# Altium schematic sheet Core.SchDoc
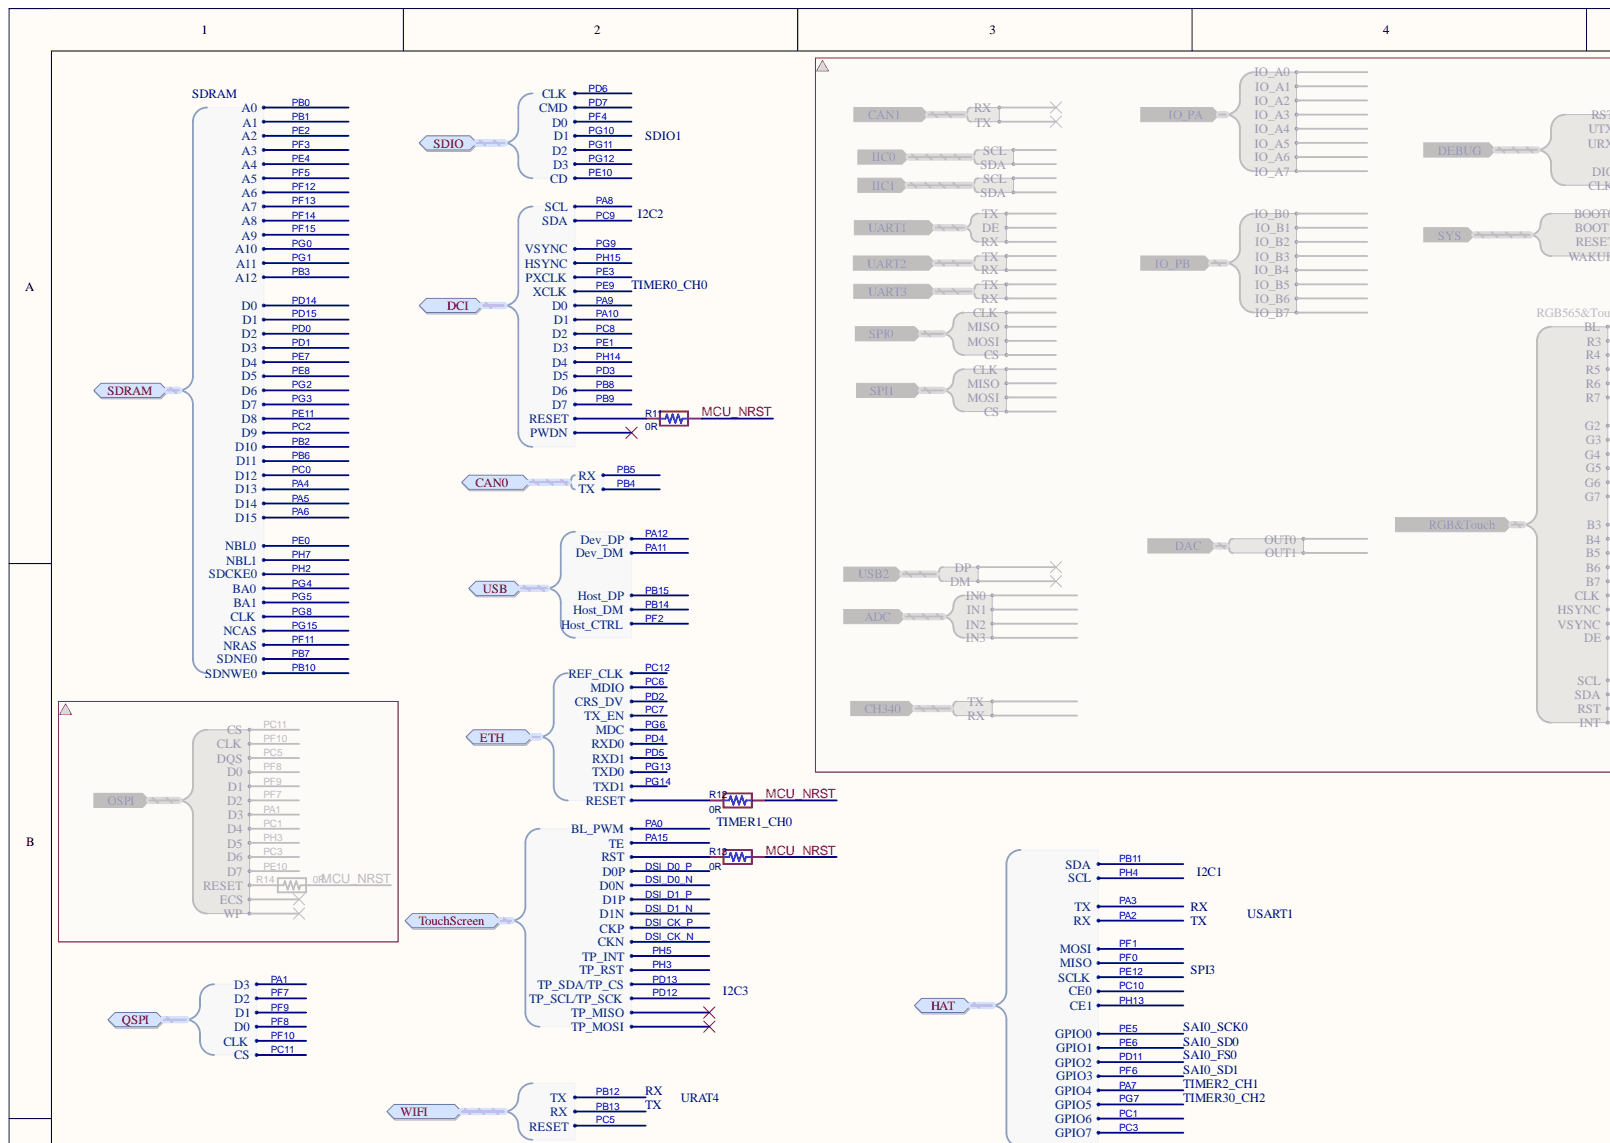
[diagram p0: Core.SchDoc, top left]
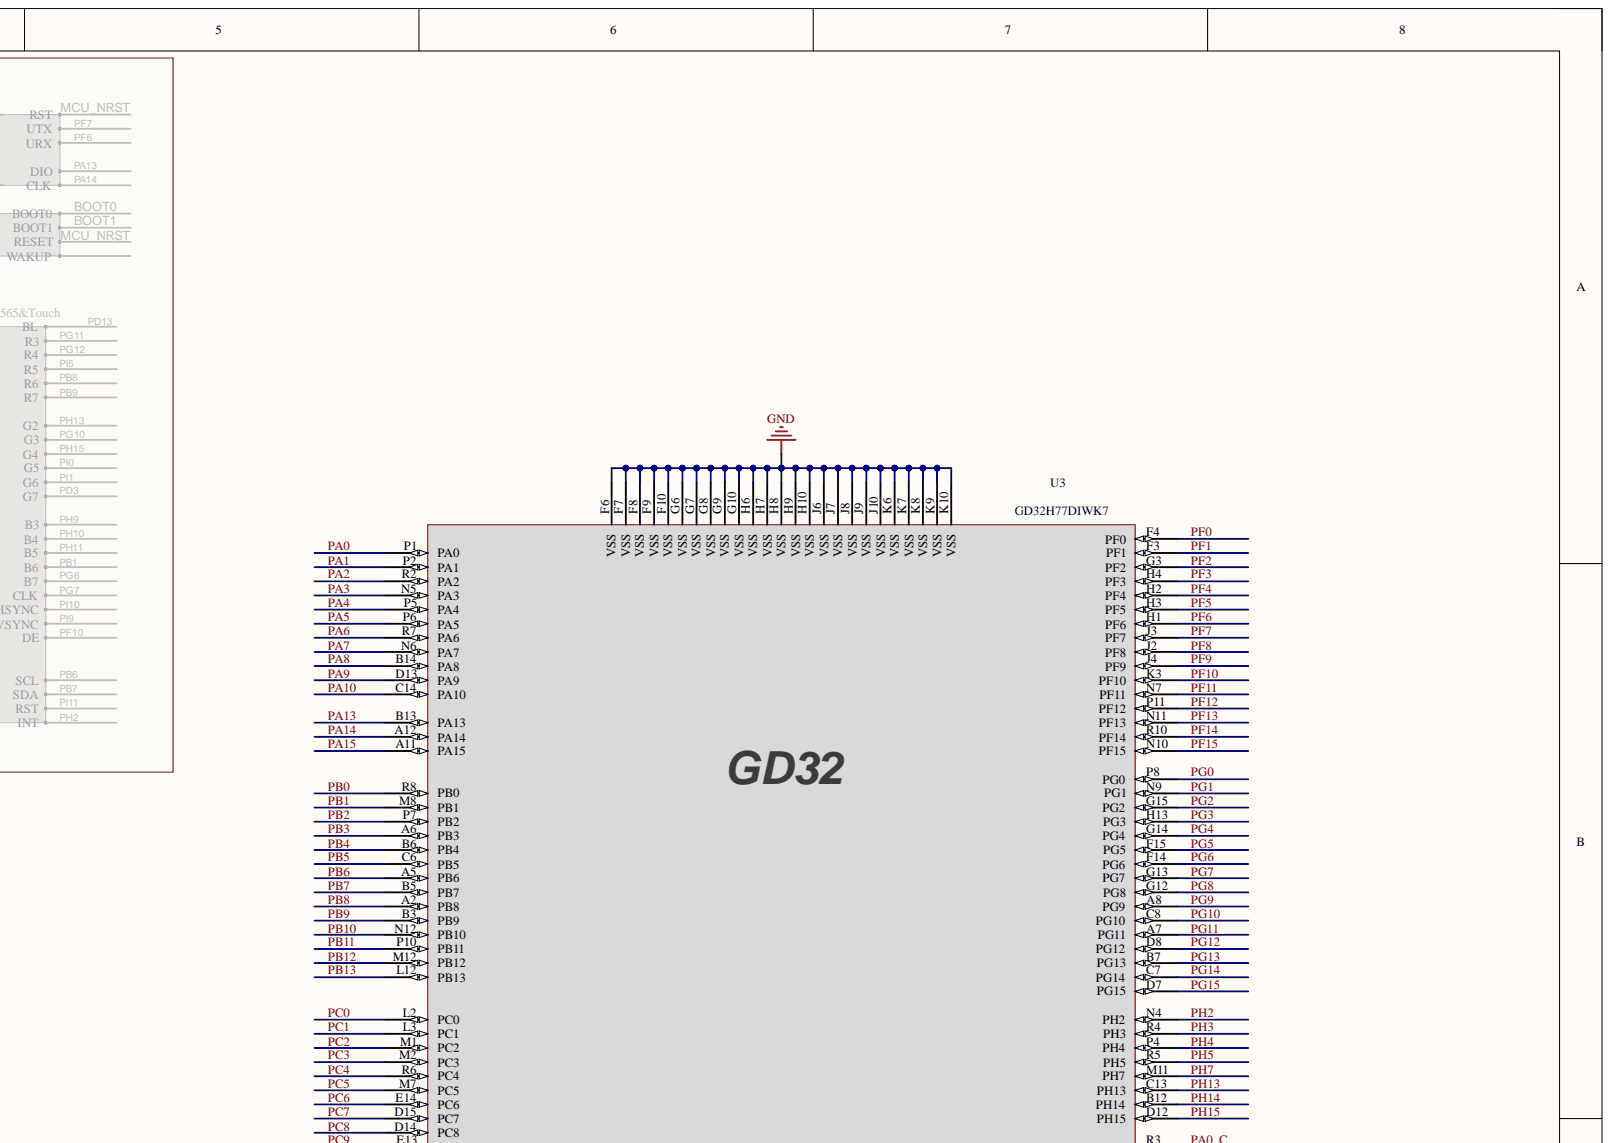
[diagram p1: Core.SchDoc, top right]
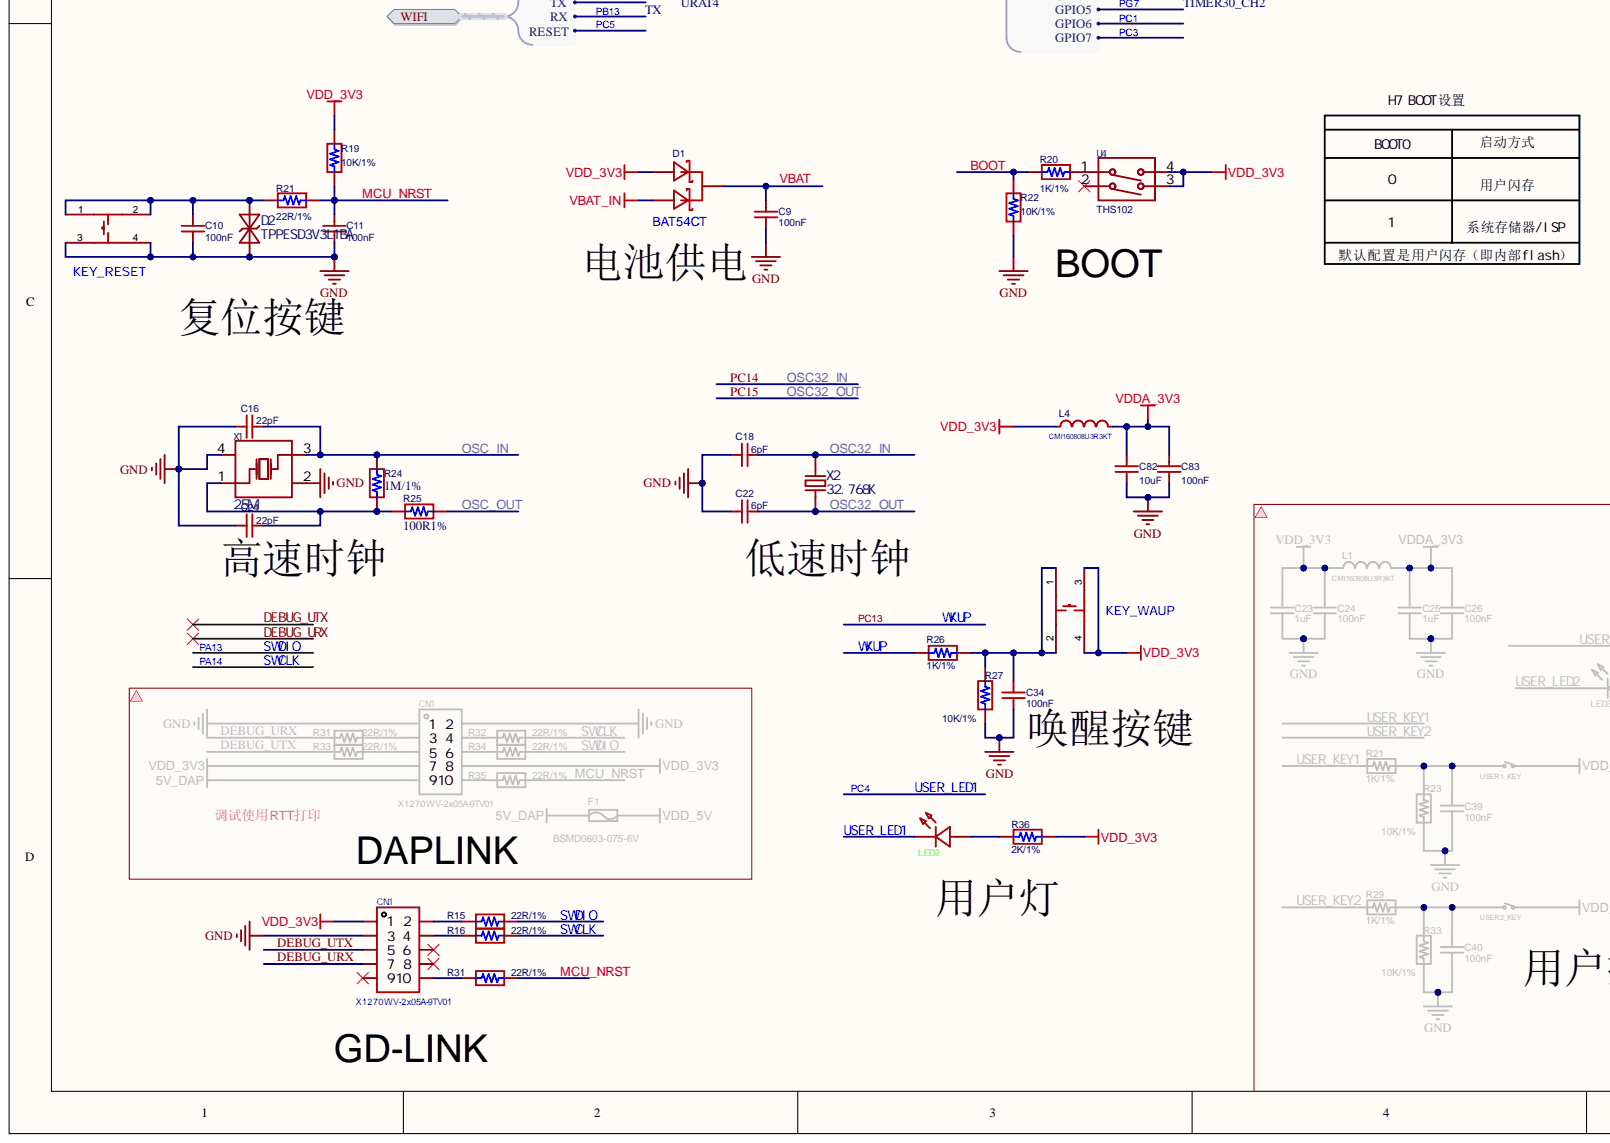
[diagram p2: Core.SchDoc, bottom left]
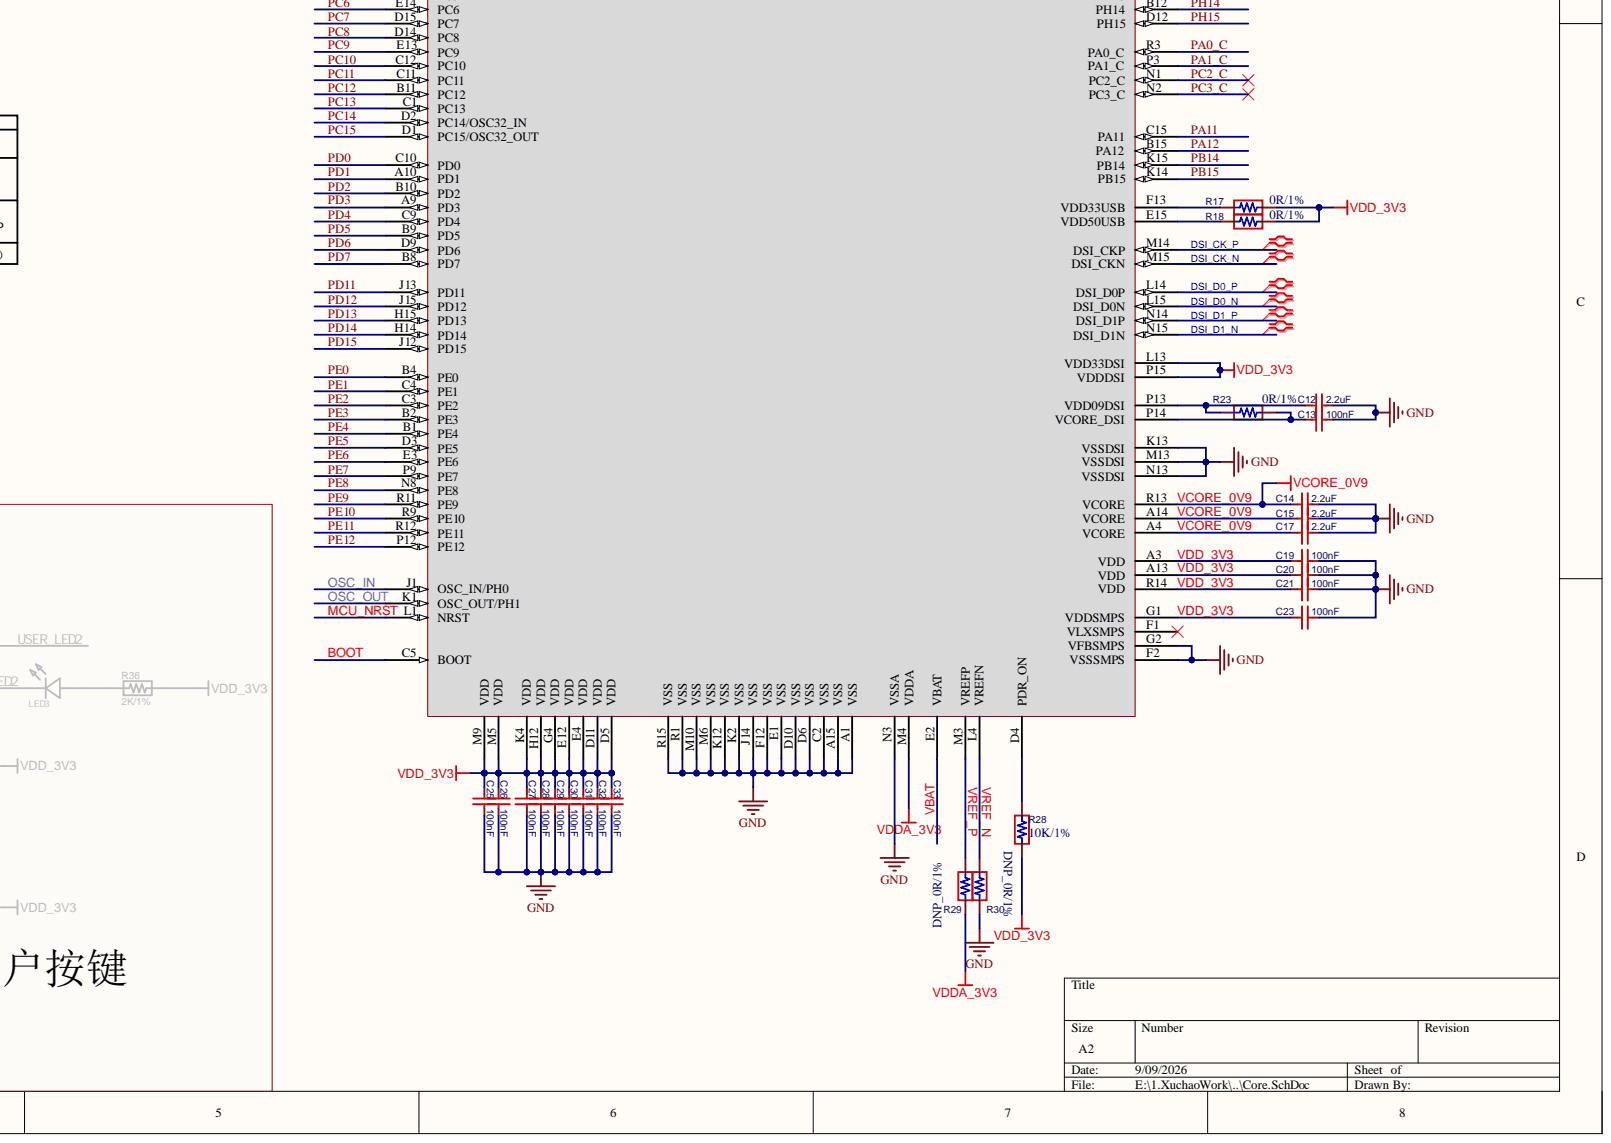
[diagram p3: Core.SchDoc, bottom right]

## Parts
C9  100nF  [C0402]
C10  100nF  [C0603]
C11  100nF  [C0603]
C12  2.2uF  [C0402]
C13  100nF  [C0402]
C14  2.2uF  [C0402]
C15  2.2uF  [C0402]
C16  22pF  [C0402]
C17  2.2uF  [C0402]
C18  6pF  [C0402]
C19  100nF  [C0402]
C20  100nF  [C0402]
C21  100nF  [C0402]
C22  6pF  [C0402]
C23  100nF  [C0402]
C24  22pF  [C0402]
C25  100nF  [C0402]
C26  100nF  [C0402]
C27  100nF  [C0402]
C28  100nF  [C0402]
C29  100nF  [C0402]
C30  100nF  [C0402]
C31  100nF  [C0402]
C32  100nF  [C0402]
C33  100nF  [C0402]
C34  100nF  [C0603]
C82  10uF  [C0402]
C83  100nF  [C0402]
CN1  X1270WV-2x05A-9TV01  [IDC-TH_10P-P1.27-X1270WV-2X05A-6TV01]
D1  BAT54CT  [SOT-523-3_L1.6-W0.8-P1.00-LS1.6-BR]
D2  TPPESD3V3L1BA  [SOD-323_L1.7-W1.3-LS2.5-BI]
L4  CMI160808U3R3KT  [L0603]
LED2  LED  [LED 0603B]
R11  0R  [R0603]
R12  0R  [R0603]
R13  0R  [R0603]
R15  22R/1%  [R0402]
R16  22R/1%  [R0402]
R17  0R/1%  [R0402]
R18  0R/1%  [R0402]
R19  10K/1%  [R0402]
R20  1K/1%  [R0402]
R21  22R/1%  [R0402]
R22  10K/1%  [R0402]
R23  0R/1%  [R0402]
R24  1M/1%  [R0402]
R25  100R1%  [R0402]
R26  1K/1%  [R0402]
R27  10K/1%  [R0402]
R28  10K/1%  [R0402]
R29  DNP_0R/1%  [R0402]
R30  DNP_0R/1%  [R0402]
R31  22R/1%  [R0402]
R36  2K/1%  [R0402]
SW1  KEY_RESET  [SW-SMD_4P-L4.2-W3.3-P2.15-LS5.1]
SW2  KEY_WAUP  [SW-SMD_4P-L4.2-W3.3-P2.15-LS5.1]
U3  GD32H77DIWK7  [BGA176_10x10X0.68]
U4  THS102  [SW-SMD_THS102]
X1  25M  [OSC 3225-4P]
X2  32.768K  [OSC-SMD_L3.2-W1.5]

## Ports
CAN0, CAN0.RX, CAN0.TX, DCI, DCI.D0, DCI.D1, DCI.D2, DCI.D3, DCI.D4, DCI.D5, DCI.D6, DCI.D7, DCI.HSYNC, DCI.PWDN, DCI.PXCLK, DCI.RESET, DCI.SCL, DCI.SDA, DCI.VSYNC, DCI.XCLK, ETH, ETH.CRS_DV, ETH.MDC, ETH.MDIO, ETH.REF_CLK, ETH.RESET, ETH.RXD0, ETH.RXD1, ETH.TX_EN, ETH.TXD0, ETH.TXD1, HAT, HAT.CE0, HAT.CE1, HAT.GPIO0, HAT.GPIO1, HAT.GPIO2, HAT.GPIO3, HAT.GPIO4, HAT.GPIO5, HAT.GPIO6, HAT.GPIO7, HAT.MISO, HAT.MOSI, HAT.RX, HAT.SCL, HAT.SCLK, HAT.SDA, HAT.TX, QSPI, QSPI.CLK, QSPI.CS, QSPI.D0, QSPI.D1, QSPI.D2, QSPI.D3, SDIO, SDIO.CD, SDIO.CLK, SDIO.CMD, SDIO.D0, SDIO.D1, SDIO.D2, SDIO.D3, SDRAM, SDRAM.A0, SDRAM.A1, SDRAM.A2, SDRAM.A3, SDRAM.A4, SDRAM.A5, SDRAM.A6, SDRAM.A7, SDRAM.A8, SDRAM.A9, SDRAM.A10, SDRAM.A11, SDRAM.A12, SDRAM.BA0, SDRAM.BA1, SDRAM.CLK, SDRAM.D0, SDRAM.D1, SDRAM.D2, SDRAM.D3, SDRAM.D4, SDRAM.D5, SDRAM.D6, SDRAM.D7, SDRAM.D8, SDRAM.D9, SDRAM.D10, SDRAM.D11, SDRAM.D12, SDRAM.D13, SDRAM.D14, SDRAM.D15, SDRAM.NBL0, SDRAM.NBL1, SDRAM.NCAS, SDRAM.NRAS, SDRAM.SDCKE0, SDRAM.SDNE0, SDRAM.SDNWE0, TouchScreen, TouchScreen.BL_PWM, TouchScreen.CKN, TouchScreen.CKP, TouchScreen.D0N, TouchScreen.D0P, TouchScreen.D1N, TouchScreen.D1P, TouchScreen.RST, TouchScreen.TE, TouchScreen.TP_INT, TouchScreen.TP_MISO, TouchScreen.TP_MOSI, TouchScreen.TP_RST, TouchScreen.TP_SCL/TP_SCK, TouchScreen.TP_SDA/TP_CS, USB, USB.Dev_DM, USB.Dev_DP, USB.Host_CTRL, USB.Host_DM, USB.Host_DP, WIFI, WIFI.RESET, WIFI.RX, WIFI.TX

## Nets
GND: C9.1 C10.1 C11.1 C12.1 C13.1 C14.1 C15.1 C16.1 C17.1 C18.1 C19.1 C20.1 C21.1 C22.1 C23.1 C24.1 C25.1 C26.1 C27.1 C28.1 C29.1 C30.1 C31.1 C32.1 C33.1 C34.1 C82.1 C83.1 CN1.3 D2.1 R22.1 R27.2 R30.2 SW1.3 SW1.4 U3.A1(VSS) U3.A15(VSS) U3.C2(VSS) U3.D6(VSS) U3.D10(VSS) U3.E1(VSS) U3.F2(VSSSMPS) U3.F6(VSS) U3.F7(VSS) U3.F8(VSS) U3.F9(VSS) U3.F10(VSS) U3.F12(VSS) U3.G2(VFBSMPS) U3.G6(VSS) U3.G7(VSS) U3.G8(VSS) U3.G9(VSS) U3.G10(VSS) U3.H6(VSS) U3.H7(VSS) U3.H8(VSS) U3.H9(VSS) U3.H10(VSS) U3.J6(VSS) U3.J7(VSS) U3.J8(VSS) U3.J9(VSS) U3.J10(VSS) U3.J14(VSS) U3.K2(VSS) U3.K6(VSS) U3.K7(VSS) U3.K8(VSS) U3.K9(VSS) U3.K10(VSS) U3.K12(VSS) U3.K13(VSSDSI) U3.M6(VSS) U3.M10(VSS) U3.M13(VSSDSI) U3.N3(VSSA) U3.N13(VSSDSI) U3.R1(VSS) U3.R15(VSS) X1.2 X1.4
VBAT_IN: D1.2
VDDA_3V3: C82.2 C83.2 L4.2 R29.2 U3.M4(VDDA)
BOOT: R20.2 R22.2 U3.C5(BOOT)
DCI: R11.2 ; port DCI
DEBUG_URX: CN1.7
DEBUG_UTX: CN1.5
DSI_CK_N: U3.M15(DSI_CKN) ; port TouchScreen
DSI_CK_P: U3.M14(DSI_CKP) ; port TouchScreen
DSI_D0_N: U3.L15(DSI_D0N) ; port TouchScreen
DSI_D0_P: U3.L14(DSI_D0P) ; port TouchScreen
DSI_D1_N: U3.N15(DSI_D1N) ; port TouchScreen
DSI_D1_P: U3.N14(DSI_D1P) ; port TouchScreen
ETH: R12.2 ; port ETH
MCU_NRST: C11.2 R11.1 R12.1 R13.1 R19.1 R21.1 R31.2 U3.L1(NRST)
OSC32_IN: C18.2 U3.D2(PC14/OSC32_IN) X2.2
OSC32_OUT: C22.2 U3.D1(PC15/OSC32_OUT) X2.1
OSC_IN: C16.2 R24.2 U3.J1(OSC_IN/PH0) X1.3
OSC_OUT: R25.2 U3.K1(OSC_OUT/PH1)
PA0: U3.P1(PA0) ; port TouchScreen
PA0_C: U3.R3(PA0_C)
PA1: U3.P2(PA1) ; port QSPI
PA1_C: U3.P3(PA1_C)
PA2: U3.R2(PA2) ; port HAT
PA3: U3.N5(PA3) ; port HAT
PA4: U3.P5(PA4) ; port SDRAM
PA5: U3.P6(PA5) ; port SDRAM
PA6: U3.R7(PA6) ; port SDRAM
PA7: U3.N6(PA7) ; port HAT
PA8: U3.B14(PA8) ; port DCI
PA9: U3.D13(PA9) ; port DCI
PA10: U3.C14(PA10) ; port DCI
PA11: U3.C15(PA11) ; port USB
PA12: U3.B15(PA12) ; port USB
PA13: R15.2 U3.B13(PA13)
PA14: R16.2 U3.A12(PA14)
PA15: U3.A11(PA15) ; port TouchScreen
PB0: U3.R8(PB0) ; port SDRAM
PB1: U3.M8(PB1) ; port SDRAM
PB2: U3.P7(PB2) ; port SDRAM
PB3: U3.A6(PB3) ; port SDRAM
PB4: U3.B6(PB4) ; port CAN0
PB5: U3.C6(PB5) ; port CAN0
PB6: U3.A5(PB6) ; port SDRAM
PB7: U3.B5(PB7) ; port SDRAM
PB8: U3.A2(PB8) ; port DCI
PB9: U3.B3(PB9) ; port DCI
PB10: U3.N12(PB10) ; port SDRAM
PB11: U3.P10(PB11) ; port HAT
PB12: U3.M12(PB12) ; port WIFI
PB13: U3.L12(PB13) ; port WIFI
PB14: U3.K15(PB14) ; port USB
PB15: U3.K14(PB15) ; port USB
PC0: U3.L2(PC0) ; port SDRAM
PC1: U3.L3(PC1) ; port HAT
PC2: U3.M1(PC2) ; port SDRAM
PC2_C: U3.N1(PC2_C)
PC3: U3.M2(PC3) ; port HAT
PC3_C: U3.N2(PC3_C)
PC4: LED2.2 U3.R6(PC4)
PC5: U3.M7(PC5) ; port WIFI
PC6: U3.E14(PC6) ; port ETH
PC7: U3.D15(PC7) ; port ETH
PC8: U3.D14(PC8) ; port DCI
PC9: U3.E13(PC9) ; port DCI
PC10: U3.C12(PC10) ; port HAT
PC11: U3.C11(PC11) ; port QSPI
PC12: U3.B11(PC12) ; port ETH
PC13: R26.2 U3.C1(PC13)
PD0: U3.C10(PD0) ; port SDRAM
PD1: U3.A10(PD1) ; port SDRAM
PD2: U3.B10(PD2) ; port ETH
PD3: U3.A9(PD3) ; port DCI
PD4: U3.C9(PD4) ; port ETH
PD5: U3.B9(PD5) ; port ETH
PD6: U3.D9(PD6) ; port SDIO
PD7: U3.B8(PD7) ; port SDIO
PD11: U3.J13(PD11) ; port HAT
PD12: U3.J15(PD12) ; port TouchScreen
PD13: U3.H15(PD13) ; port TouchScreen
PD14: U3.H14(PD14) ; port SDRAM
PD15: U3.J12(PD15) ; port SDRAM
PE0: U3.B4(PE0) ; port SDRAM
PE1: U3.C4(PE1) ; port DCI
PE2: U3.C3(PE2) ; port SDRAM
PE3: U3.B2(PE3) ; port DCI
PE4: U3.B1(PE4) ; port SDRAM
PE5: U3.D3(PE5) ; port HAT
PE6: U3.E3(PE6) ; port HAT
PE7: U3.P9(PE7) ; port SDRAM
PE8: U3.N8(PE8) ; port SDRAM
PE9: U3.R11(PE9) ; port DCI
PE10: U3.R9(PE10) ; port SDIO
PE11: U3.R12(PE11) ; port SDRAM
PE12: U3.P12(PE12) ; port HAT
PF0: U3.F4(PF0) ; port HAT
PF1: U3.F3(PF1) ; port HAT
PF2: U3.G3(PF2) ; port USB
PF3: U3.H4(PF3) ; port SDRAM
PF4: U3.H2(PF4) ; port SDIO
PF5: U3.H3(PF5) ; port SDRAM
PF6: U3.H1(PF6) ; port HAT
PF7: U3.J3(PF7) ; port QSPI
PF8: U3.J2(PF8) ; port QSPI
PF9: U3.J4(PF9) ; port QSPI
PF10: U3.K3(PF10) ; port QSPI
PF11: U3.N7(PF11) ; port SDRAM
PF12: U3.P11(PF12) ; port SDRAM
PF13: U3.N11(PF13) ; port SDRAM
PF14: U3.R10(PF14) ; port SDRAM
PF15: U3.N10(PF15) ; port SDRAM
PG0: U3.P8(PG0) ; port SDRAM
PG1: U3.N9(PG1) ; port SDRAM
PG2: U3.G15(PG2) ; port SDRAM
PG3: U3.H13(PG3) ; port SDRAM
PG4: U3.G14(PG4) ; port SDRAM
PG5: U3.F15(PG5) ; port SDRAM
PG6: U3.F14(PG6) ; port ETH
PG7: U3.G13(PG7) ; port HAT
PG8: U3.G12(PG8) ; port SDRAM
PG9: U3.A8(PG9) ; port DCI
PG10: U3.C8(PG10) ; port SDIO
PG11: U3.A7(PG11) ; port SDIO
PG12: U3.D8(PG12) ; port SDIO
PG13: U3.B7(PG13) ; port ETH
PG14: U3.C7(PG14) ; port ETH
PG15: U3.D7(PG15) ; port SDRAM
PH2: U3.N4(PH2) ; port SDRAM
PH3: U3.R4(PH3) ; port TouchScreen
PH4: U3.P4(PH4) ; port HAT
PH5: U3.R5(PH5) ; port TouchScreen
PH7: U3.M11(PH7) ; port SDRAM
PH13: U3.C13(PH13) ; port HAT
PH14: U3.B12(PH14) ; port DCI
PH15: U3.D12(PH15) ; port DCI
TouchScreen: R13.2 ; port TouchScreen
VBAT: C9.2 D1.3 U3.E2(VBAT)
VCORE_0V9: C14.2 C15.2 C17.2 U3.A4(VCORE) U3.A14(VCORE) U3.R13(VCORE)
VDD_3V3: C19.2 C20.2 C21.2 C23.2 C25.2 C26.2 C27.2 C28.2 C29.2 C30.2 C31.2 C32.2 C33.2 CN1.1 D1.1 L4.1 R17.2 R18.2 R19.2 R28.2 R36.1 SW2.3 SW2.4 U3.A3(VDD) U3.A13(VDD) U3.D5(VDD) U3.D11(VDD) U3.E4(VDD) U3.E12(VDD) U3.G1(VDDSMPS) U3.G4(VDD) U3.H12(VDD) U3.K4(VDD) U3.L13(VDD33DSI) U3.M5(VDD) U3.M9(VDD) U3.P15(VDDDSI) U3.R14(VDD) U4.3 U4.4
VREF_N: R30.1 U3.L4(VREFN)
VREF_P: R29.1 U3.M3(VREFP)
NetC10_2: C10.2 D2.2 R21.2 SW1.1 SW1.2
NetC12_2: C12.2 R23.1 U3.P13(VDD09DSI)
NetC13_2: C13.2 R23.2 U3.P14(VCORE_DSI)
NetC24_2: C24.2 R24.1 R25.1 X1.1
NetC34_2: C34.2 R26.1 R27.1 SW2.1 SW2.2
NetCN1_2: CN1.2 R15.1
NetCN1_4: CN1.4 R16.1
NetCN1_6: CN1.6
NetCN1_8: CN1.8
NetCN1_9: CN1.9
NetCN1_10: CN1.10 R31.1
NetLED2_1: LED2.1 R36.2
NetR17_1: R17.1 U3.F13(VDD33USB)
NetR18_1: R18.1 U3.E15(VDD50USB)
NetR20_1: R20.1 U4.1
NetR28_1: R28.1 U3.D4(PDR_ON)
NetU3_F1: U3.F1(VLXSMPS)
NetU4_2: U4.2
N00001: ; port DCI
N00002: ; port TouchScreen
N00003: ; port TouchScreen

## Library data of the parts
C9-C34 C82 C83 = 0SMD_CAP: Symbol: CL10A106KP8NNNC; Component Kind: Standard; Library Name: CYKJ_LibPkg.IntLib
CN1 = X1270WV-2x05A-9TV01: Manufacturer: XKB Connection(中国星坤); Add into BOM: yes; Symbol: X1270WV-2X05A-6TV01; Component Kind: Standard; Connector Type: -; Contact Material: -; Contact Plating: -; Convert to PCB: yes; Current Rating: -; Datasheet: https://item.szlcsc.com/datasheet/X1270WV-2x05A-9TV01/3351704.html; Device: X1270WV-2x05A-9TV01; Holder Type: -; JLCPCB Part Class: Extended Part; LCSC Part Name: X1270WV-2x05A-6TV01; Manufacturer Part: X1270WV-2x05A-9TV01; Number of PINs Per Row: -; Number of Rows: -; Operating Temperature: -; Pitch: -; Row Spacing: -; Supplier: LCSC; Supplier Footprint: 插件,P=1.27mm; Supplier Part: C2962228; Voltage Rating (Max): -; Library Name: CYKJ_LibPkg.IntLib
D1 = BAT54CT: 肖特基二极管,C77320; Component Kind: Standard; Symbol: BAT54CT; Library Name: CYKJ_library.SchLib
D2 = TVS_TPPESD3V3L1BA: Manufacturer: TECH PUBLIC(台舟); Add into BOM: yes; Type: ESD; Component Kind: Standard; Convert to PCB: yes; Datasheet: https://atta.szlcsc.com/upload/public/pdf/source/20210810/6217B539235FF85CFB2AA22F30B6908F.pdf; JLCPCB Part Class: 扩展库; LCSC Part Name: TPPESD3V3L1BA; Manufacturer Part: TPPESD3V3L1BA; Supplier: LCSC; Supplier Footprint: SOD-323; Supplier Part: C2682272; Symbol: TPPESD3V3L1BA; Library Name: CYKJ_LibPkg.IntLib
L4 = 0SMD_Inductor: Component Kind: Standard; Symbol: CMI160808U3R3KT; Library Name: CYKJ_LibPkg.IntLib
LED2 = 0SMD_LED: Manufacturer: XINGLIGHT(成兴光); Add into BOM: yes; Device: XL-1608UBC-04; Forward Current: 20mA; Illumination Color: 蓝色; Lens Color: 无色透明透镜; Luminous Intensity: 300mcd; Peak Wavelength: 470nm; Power(Watts): 70mW; Viewing Angle: 120°; Voltage - Forward(Vf): 3.3V; Wavelength - Dominant: 455nm~475nm; Color Temperature: -; Component Kind: Standard; Convert to PCB: yes; Datasheet: https://item.szlcsc.com/datasheet/XL-1608UBC-04/1051416.html; Diode Configuration: -; JLCPCB Part Class: Extended Part; Lamp Holder Type: -; LCSC Part Name: 0603蓝色贴片式发光二极管; Manufacturer Part: XL-1608UBC-04; Mounting Style: 正贴; Operating Temperature: -; Supplier: LCSC; Supplier Footprint: 0603; Supplier Part: C965807; Symbol: XL-1608UBC-04; Library Name: CYKJ_library.SchLib
R11-R13 R15-R31 R36 = Resisteors: RES_SMD; Component Kind: Standard; Library Name: CYKJ_library.SchLib
SW1 SW2 = SW_PTS815SJK250SMTRLFS: 电路结构:单刀单掷-常开;按钮形状:椭圆形按钮;作用力:2.5N;安装方式:立贴;触点电流:50mA;额定电压(DC):12V;; Manufacturer: C&K; Actuator Style: 椭圆形按钮; AddInBom: yes; Contact Current: 50mA; IP Rating: IP40; ModelId: 101da67941e24d4e9615179a94fc6132; ModelName: SW-SMD_4P-L4.2-W3.3-H2.5-P2.15-LS5.1; ModelTransform: 204.724,127.9525,0,0,0,0,0,0,0; Operating Force: 2.5N; Pin Style: J型引脚; Voltage Rating (DC): 12V; Circuit: 单刀单掷-常开; Component Kind: Standard; ConvertToPcb: yes; Datasheet: https://atta.szlcsc.com/upload/public/pdf/source/20190916/C411883_4F77F16E1D8E48DC707D8949CEE7CF80.pdf; FootprintName: SW-SMD_4P-L4.2-W3.3-P2.15-LS5.1; JLCPCB Part Class: Extended Part; LCSC Part Name: 轻触开关; ManufacturerPart: PTS815SJK250SMTRLFS; Mounting Style: 立贴; Operating Temperature: -20℃~+70℃; Supplier: LCSC; Supplier Footprint: SMD; SupplierPart: C918859; Symbol: PTS815SJK250SMTRLFS; Library Name: CYKJ_LibPkg.IntLib
U3 = MCU_GD32H77DIxK7: Component Kind: Standard; Library Name: CYKJ_LibPkg.IntLib
U4 = THS102: 拨码开关; Manufacturer: ROCPU Switches(台普); Add into BOM: yes; Circuit: 单刀单掷; Current Rating: 25mA; Number of Positions: 2位; Operating Life: 3千次; Voltage Rating: 24V; Color: 黑色; Component Kind: Standard; Convert to PCB: yes; Datasheet: https://atta.szlcsc.com/upload/public/pdf/source/20210423/C2758162_2BB9D4AC1FA36EBF9E7F3DB83D8A8104.pdf; Device: THS102; LCSC Part Name: THS102; Lead Pitch: 1.27mm; Manufacturer Part: THS102; Operating Temperature: -30℃~+85℃; Pin Style: -; Supplier: LCSC; Supplier Footprint: SMD-4P,4.1x5.4mm; Supplier Part: C2758158; Symbol: THS102; Type: 平拨,凹槽式; Library Name: CYKJ_library.SchLib
X1 = OSC_X322525MMB4SI: OSC_3225; Manufacturer: YXC(扬兴晶振); Add into BOM: yes; Crystal Type: 贴片无源晶振; Frequency Stability: ±30ppm; Frequency Tolerance: ±10ppm; Load Capacitance: 10pF; Component Kind: Standard; Convert to PCB: yes; Datasheet: https://atta.szlcsc.com/upload/public/pdf/source/20210329/C112971_1F611BDC486E193C1706F6D8EB5AA189.pdf; Device: X322525MMB4SI; JLCPCB Part Class: 扩展库; LCSC Part Name: 25MHz ±10ppm 10pF YSX321SL; Manufacturer Part: X322525MMB4SI; Operating Temperature: -40℃~+85℃; Supplier: LCSC; Supplier Footprint: SMD3225-4P; Supplier Part: C70582; Symbol: X322525MMB4SI; Library Name: CYKJ_LibPkg.IntLib
X2 = OSC_X321532768KGD2SI: 32.768k,贴片晶振; Manufacturer: YXC(扬兴晶振); Add into BOM: yes; Crystal Type: 贴片无源晶振; Frequency Tolerance: ±20ppm; Load Capacitance: 12.5pF; Component Kind: Standard; Convert to PCB: yes; Datasheet: https://atta.szlcsc.com/upload/public/pdf/source/20210329/C620155_E5A74FC0D27C5E9015A8D75DE9484FEA.pdf; Device: X321532768KGD2SI; JLCPCB Part Class: 扩展库; LCSC Part Name: 32.768kHz ±20ppm 12.5pF YST310S; Manufacturer Part: X321532768KGD2SI; Operating Temperature: -40℃~+85℃; Supplier: LCSC; Supplier Footprint: SMD3215-2P; Supplier Part: C620155; Symbol: X321532768KGD2SI; Library Name: CYKJ_LibPkg.IntLib
